# Altium schematic sheet Power_MCU.SchDoc(Power_MCU)
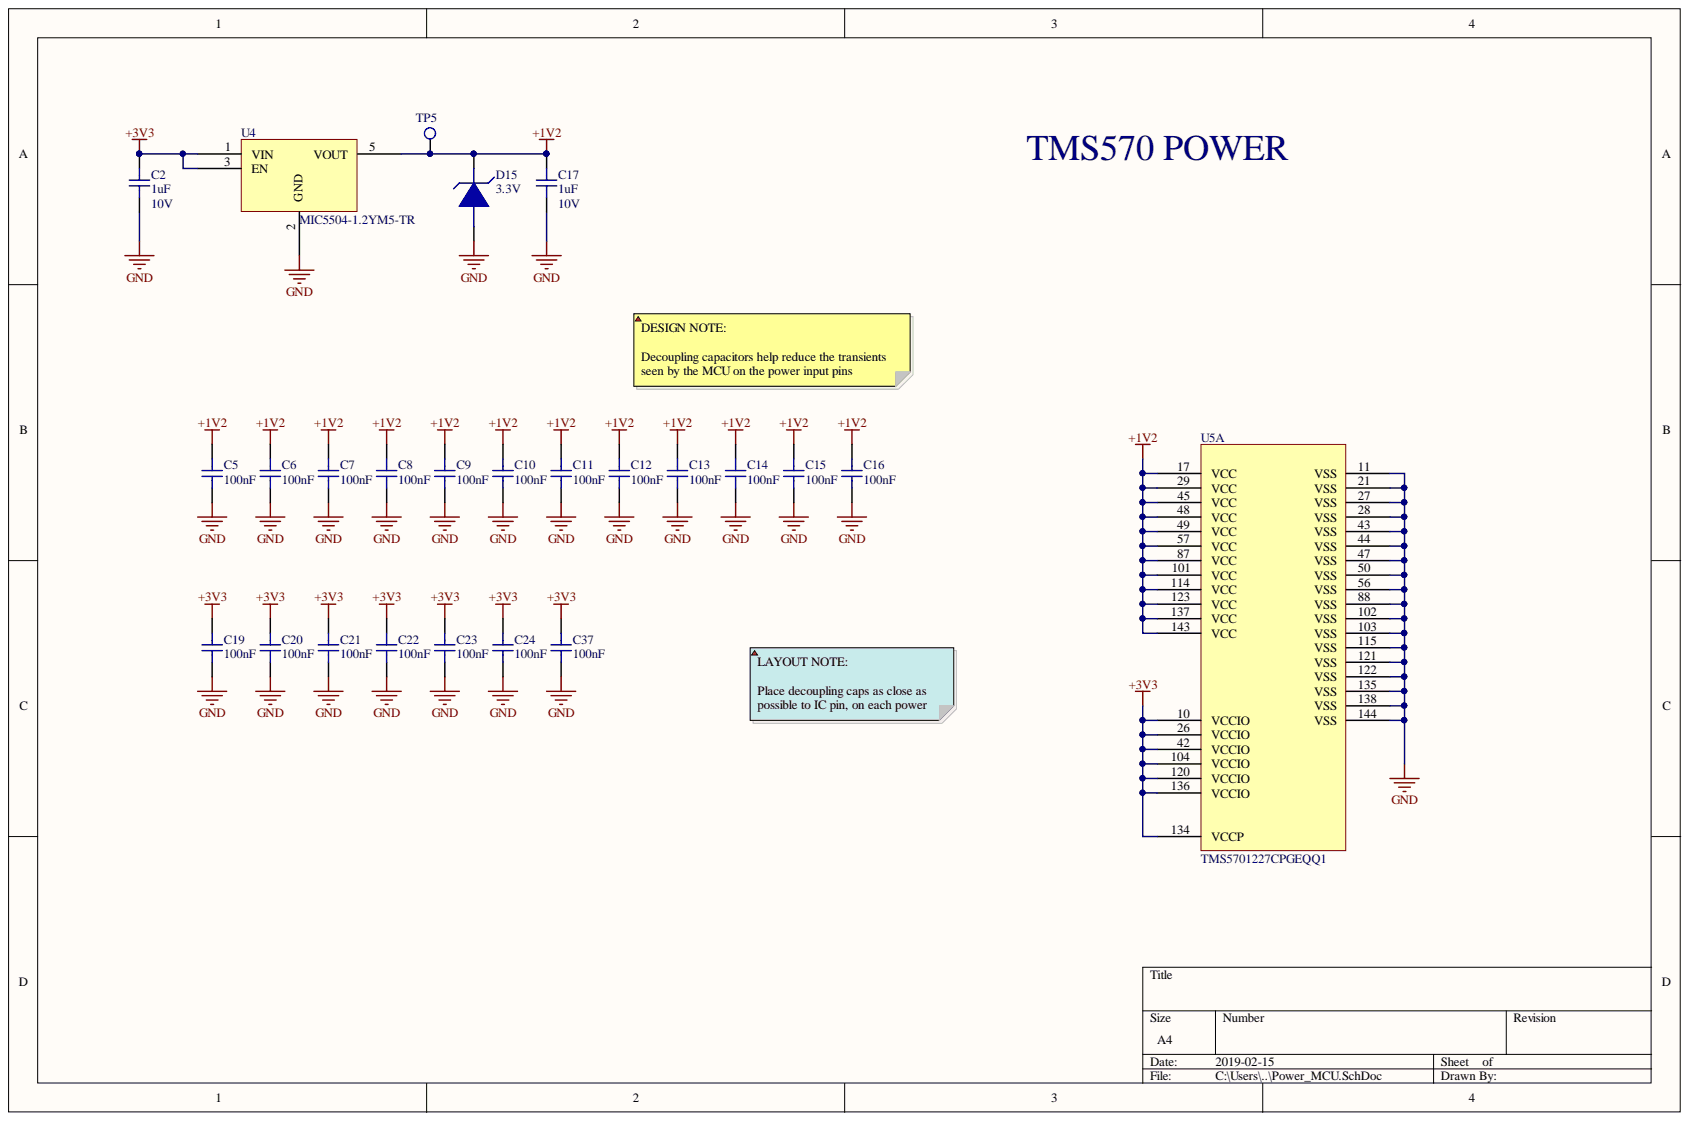
[diagram p0: Power_MCU.SchDoc]

## Parts
C2  1uF  Voltage Rating (DC): 10V  [C0402]
C5  100nF  [C0402]
C6  100nF  [C0402]
C7  100nF  [C0402]
C8  100nF  [C0402]
C9  100nF  [C0402]
C10  100nF  [C0402]
C11  100nF  [C0402]
C12  100nF  [C0402]
C13  100nF  [C0402]
C14  100nF  [C0402]
C15  100nF  [C0402]
C16  100nF  [C0402]
C17  1uF  Voltage Rating (DC): 10V  [C0402]
C19  100nF  [C0402]
C20  100nF  [C0402]
C21  100nF  [C0402]
C22  100nF  [C0402]
C23  100nF  [C0402]
C24  100nF  [C0402]
C37  100nF  [C0402]
D15  3.3V  [DIODE_TVS]
TP5  5015  [TP_HOOK]  (value not drawn)
U4  MIC5504-1.2YM5-TR  [SOT23-5-MIC5504]
U5  TMS5701227CPGEQQ1  [LQFP-144]  (part A)

## Nets
+1V2: C5.2 C6.2 C7.2 C8.2 C9.2 C10.2 C11.2 C12.2 C13.2 C14.2 C15.2 C16.2 C17.2 D15.1 TP5.1 U4.5(VOUT) U5.17(VCC) U5.29(VCC) U5.45(VCC) U5.48(VCC) U5.49(VCC) U5.57(VCC) U5.87(VCC) U5.101(VCC) U5.114(VCC) U5.123(VCC) U5.137(VCC) U5.143(VCC)
+3V3: C2.2 C19.2 C20.2 C21.2 C22.2 C23.2 C24.2 C37.2 U4.1(VIN) U4.3(EN) U5.10(VCCIO) U5.26(VCCIO) U5.42(VCCIO) U5.104(VCCIO) U5.120(VCCIO) U5.134(VCCP) U5.136(VCCIO)
GND: C2.1 C5.1 C6.1 C7.1 C8.1 C9.1 C10.1 C11.1 C12.1 C13.1 C14.1 C15.1 C16.1 C17.1 C19.1 C20.1 C21.1 C22.1 C23.1 C24.1 C37.1 D15.2 U4.2(GND) U5.11(VSS) U5.21(VSS) U5.27(VSS) U5.28(VSS) U5.43(VSS) U5.44(VSS) U5.47(VSS) U5.50(VSS) U5.56(VSS) U5.88(VSS) U5.102(VSS) U5.103(VSS) U5.115(VSS) U5.121(VSS) U5.122(VSS) U5.135(VSS) U5.138(VSS) U5.144(VSS)

## Library data of the parts
C2 C17 = CL05A105MP5NNNC: CAP MLCC 1uF 10V 0402 X5R 20% SMD 0402 85C Paper T/R; Manufacturer: Samsung; Width: 0.02inch; Voltage Rating: 10V; Tolerance: 20%; Thickness: 0.022inch; Supplier Part Number 1: 1276-1448-1-ND; Supplier 1: Digi-Key; Stock 1: 100000; Radiation Hardening: No; Pricing 1: 1=0.1, 10=0.031, 100=0.014, 500=0.01, 1000=0.0079, 2500=0.0072, 5000=0.0066 (USD); Packaging: Tape and Reel; Package Quantity: 1; Mount: Surface Mount; Min Operating Temperature: -55°C; Max Operating Temperature: 85°C; Manufacturer Part Number: CL05A105MP5NNNC; Length: 1mm; Lead Free: Lead Free; Height: 0.5mm; Dielectric: X5R; Depth: 0.5mm; ComponentLink1URL: https://media.digikey.com/pdf/Data%20Sheets/Samsung%20PDFs/CL_Series_MLCC_ds.pdf; ComponentLink1Description: https://media.digikey.com/pdf/Data%20Sheets/Samsung%20PDFs/CL_Series_MLCC_ds.pdf; Component Kind: Standard; Case Code (Metric): 1005; Case Code (Imperial): 0402; Case/Package: 0402; Capacitance: 1uF; Library Name: PHANTOM_LIBRARY.SchLib
C5-C16 C19-C24 C37 = CL05A104MP5NNNC: CAP MLCC 0.1uF 10V X5R 0402 20% SMD 0402 85C Paper T/R; Manufacturer: Samsung; Width: 0.02inch; Voltage Rating (DC): 10V; Voltage Rating: 10V; Tolerance: 20%; Thickness: 0.022inch; Supplier Part Number 1: 1276-1443-1-ND; Supplier 1: Digi-Key; Stock 1: 100000; Radiation Hardening: No; Pricing 1: 1=0.1, 10=0.024, 100=0.0106, 500=0.0076, 1000=0.006, 2500=0.0054, 5000=0.005 (USD); Packaging: Tape and Reel; Mount: Surface Mount; Min Operating Temperature: -55°C; Max Operating Temperature: 85°C; Manufacturer Part Number: CL05A104MP5NNNC; Length: 1mm; Lead Free: Lead Free; Height: 0.5mm; Dielectric: X5R; Depth: 0.5mm; ComponentLink1URL: https://media.digikey.com/pdf/Data%20Sheets/Samsung%20PDFs/CL_Series_MLCC_ds.pdf; ComponentLink1Description: https://media.digikey.com/pdf/Data%20Sheets/Samsung%20PDFs/CL_Series_MLCC_ds.pdf; Component Kind: Standard; Case Code (Metric): 1005; Case Code (Imperial): 0402; Case/Package: 0402; Capacitance: 100nF; Library Name: PHANTOM_LIBRARY.SchLib
D15 = 1SMA5913BT3G: DIODE ZENER 3.3V 1.5W SMA; Manufacturer: ON Semiconductor; Zener Current: 455mA; Voltage Tolerance: 5%; Test Current: 113.6mA; Power Dissipation: 500mW; Peak Reverse Current: 50uA; Material: Plastic; Impedance: 10R; Forward Voltage: 1.5V; Element Configuration: Single; Breakdown Voltage: 3.47V; Zener Voltage: 3.3V; Working Voltage: 3.3V; Width: 2.92mm; Voltage Rating (DC): 3.3V; Tolerance: 5%; Supplier Part Number 1: 1SMA5913BT3GOSCT-ND; Supplier 1: Digi-Key; Stock 1: 12228; REACH SVHC: No SVHC; Radiation Hardening: No; Pricing 1: 1=0.38, 10=0.305, 100=0.2076, 500=0.1557, 1000=0.1168 (USD); Power Rating: 1.5W; Packaging: Tape and Reel; Package Quantity: 1; Number of Pins: 2; Min Operating Temperature: -65°C; Max Reverse Leakage Current: 50uA; Max Power Dissipation: 1.5W; Max Operating Temperature: 150°C; Manufacturer Part Number: 1SMA5913BT3G; Length: 4.57mm; Lead Free: Lead Free; Height: 2.05mm; Halogen Free: Halogen Free; ComponentLink13URL: https://datasheet.ciiva.com/26092/1sma5913bt3-d-26092398.pdf; ComponentLink13Description: https://datasheet.ciiva.com/26092/1sma5913bt3-d-26092398.pdf; ComponentLink12URL: https://datasheet.ciiva.com/8026/1sma5913bt3-d-8026990.pdf; ComponentLink12Description: https://datasheet.ciiva.com/8026/1sma5913bt3-d-8026990.pdf; ComponentLink11URL: https://datasheet.ciiva.com/8026/1sma5913bt3-d-8026989.pdf; ComponentLink11Description: https://datasheet.ciiva.com/8026/1sma5913bt3-d-8026989.pdf; ComponentLink10URL: https://datasheet.ciiva.com/49027/70339279-49027772.pdf; ComponentLink10Description: https://datasheet.ciiva.com/49027/70339279-49027772.pdf; ComponentLink9URL: https://datasheet.ciiva.com/16472/1sma5913bt3-d-16472764.pdf; ComponentLink9Description: https://datasheet.ciiva.com/16472/1sma5913bt3-d-16472764.pdf; ComponentLink8URL: https://datasheet.ciiva.com/895/1sma5913bt3-d-895308.pdf; ComponentLink8Description: https://datasheet.ciiva.com/895/1sma5913bt3-d-895308.pdf; ComponentLink7URL: https://datasheet.ciiva.com/4733/1sma5913bt3-d-4733195.pdf; ComponentLink7Description: https://datasheet.ciiva.com/4733/1sma5913bt3-d-4733195.pdf; ComponentLink6URL: https://datasheet.ciiva.com/895/1sma5913bt3-d-895307.pdf; ComponentLink6Description: https://datasheet.ciiva.com/895/1sma5913bt3-d-895307.pdf; ComponentLink5URL: https://datasheet.ciiva.com/15142/getdatasheetpartid-90498-15142554.pdf; ComponentLink5Description: https://datasheet.ciiva.com/15142/getdatasheetpartid-90498-15142554.pdf; ComponentLink4URL: https://datasheet.ciiva.com/895/1sma5913bt3-d-895306.pdf; ComponentLink4Description: https://datasheet.ciiva.com/895/1sma5913bt3-d-895306.pdf; ComponentLink3URL: https://datasheet.ciiva.com/8346/1sma5913bt3-d-8346488.pdf; ComponentLink3Description: https://datasheet.ciiva.com/8346/1sma5913bt3-d-8346488.pdf; ComponentLink2URL: https://datasheet.ciiva.com/8345/1sma5913bt3-d-8345864.pdf; ComponentLink2Description: https://datasheet.ciiva.com/8345/1sma5913bt3-d-8345864.pdf; ComponentLink1URL: https://datasheet.ciiva.com/8026/1sma5913bt3-d-8026917.pdf; ComponentLink1Description: https://datasheet.ciiva.com/8026/1sma5913bt3-d-8026917.pdf; Component Kind: Standard; Case/Package: SMA; Library Name: PHANTOM_LIBRARY.SchLib
TP5 = 5015: TEST POINT, PCB, SMT; Manufacturer: Keystone Electronics; Number of Ports: 1; Thickness: 0.01inch; Termination: Solder; Supplier Part Number 1: 36-5015CT-ND; Supplier 1: Digi-Key; Stock 1: 1937775; REACH SVHC: No SVHC; Pricing 1: 1=0.44, 10=0.421, 25=0.3604, 50=0.3064, 100=0.2944, 250=0.2644, 500=0.2524 (USD); Packaging: Tape and Reel; Package Quantity: 1; Orientation: Straight; Mount: Surface Mount; Material: Bronze,Silver; Manufacturer Part Number: 5015; Length: 2.66mm; Lead Free: Lead Free; Height: 0.06inch; Depth: 1.01mm; Contact Plating: Tin,Silver,Lead; Contact Material: Bronze; ComponentLink4URL: https://datasheet.ciiva.com/16883/70182998-16883734.pdf; ComponentLink4Description: https://datasheet.ciiva.com/16883/70182998-16883734.pdf; ComponentLink3URL: https://datasheet.ciiva.com/1123/5015-1123862.pdf; ComponentLink3Description: https://datasheet.ciiva.com/1123/5015-1123862.pdf; ComponentLink2URL: https://datasheet.ciiva.com/2917/5015-2917617.pdf; ComponentLink2Description: https://datasheet.ciiva.com/2917/5015-2917617.pdf; ComponentLink1URL: https://datasheet.ciiva.com/2917/5015-2917616.pdf; ComponentLink1Description: https://datasheet.ciiva.com/2917/5015-2917616.pdf; Component Kind: Standard; Colour: White; Library Name: PHANTOM_LIBRARY.SchLib
U4 = MIC5504-1.2YM5-TR: IC LDO 1.2V 300mA Enable Pull-Down & Auto Discharge, 5 SOT-23 T/R; Manufacturer: Microchip; Number of Regulators: 1; Min Current Limit: 400mA; Dropout Voltage: 160mV; Supplier Part Number 1: 576-4878-1-ND; Supplier 1: Digi-Key; Stock 1: 479; Pricing 1: 1=0.11, 25=0.0928, 100=0.0824 (USD); Packaging: Cut Tape; Output Voltage: 1.2V; Output Current: 300mA; Mount: Surface Mount; Min Operating Temperature: -40°C; Max Operating Temperature: 125°C; Manufacturer Part Number: MIC5504-1.2YM5-TR; Component Kind: Standard; Case/Package: SOT-23-5; Library Name: PHANTOM_LIBRARY.SchLib
U5 = TMS5701227CPGEQQ1: IC MCU 16-Bit/32-Bit Hercules TMS570 ARM Cortex R4F RISC 1280KB Flash 1.2V/3.3V 144-Pin LQFP; Manufacturer: Texas Instruments; Watchdog Timer: Yes; RAM Size: 192KB; Peripherals: DMA,POR,PWM,WDT; Oscillator Type: External; Number of Timers/Counters: 2; Number of Programmable I/O: 58; Memory Size: 1.25MB; Max Frequency: 160MHz; Interface: CAN,EBI/EMI,Ethernet,I2C,LIN,SCI,SPI,UART,USART; Density: 1310720B; Supplier Part Number 1: 296-42451-ND; Supplier 1: Digi-Key; Stock 1: 2872; Pricing 1: 1=24.99, 10=23.046, 60=22.0098, 120=19.6794, 540=17.8669 (USD); Packaging: Tray; Number of Pins: 144; Number of I/Os: 58; Number of GPIO: 58; Min Operating Temperature: -40°C; Memory Type: FLASH; Max Operating Temperature: 125°C; Manufacturer Part Number: TMS5701227CPGEQQ1; Lead Free: Lead Free; Frequency: 160MHz; Core Architecture: ARM; Component Kind: Standard; Case/Package: LQFP; Library Name: PHANTOM_LIBRARY.SchLib
